# Altium schematic sheet npm1300_qeaa_config3.SchDoc
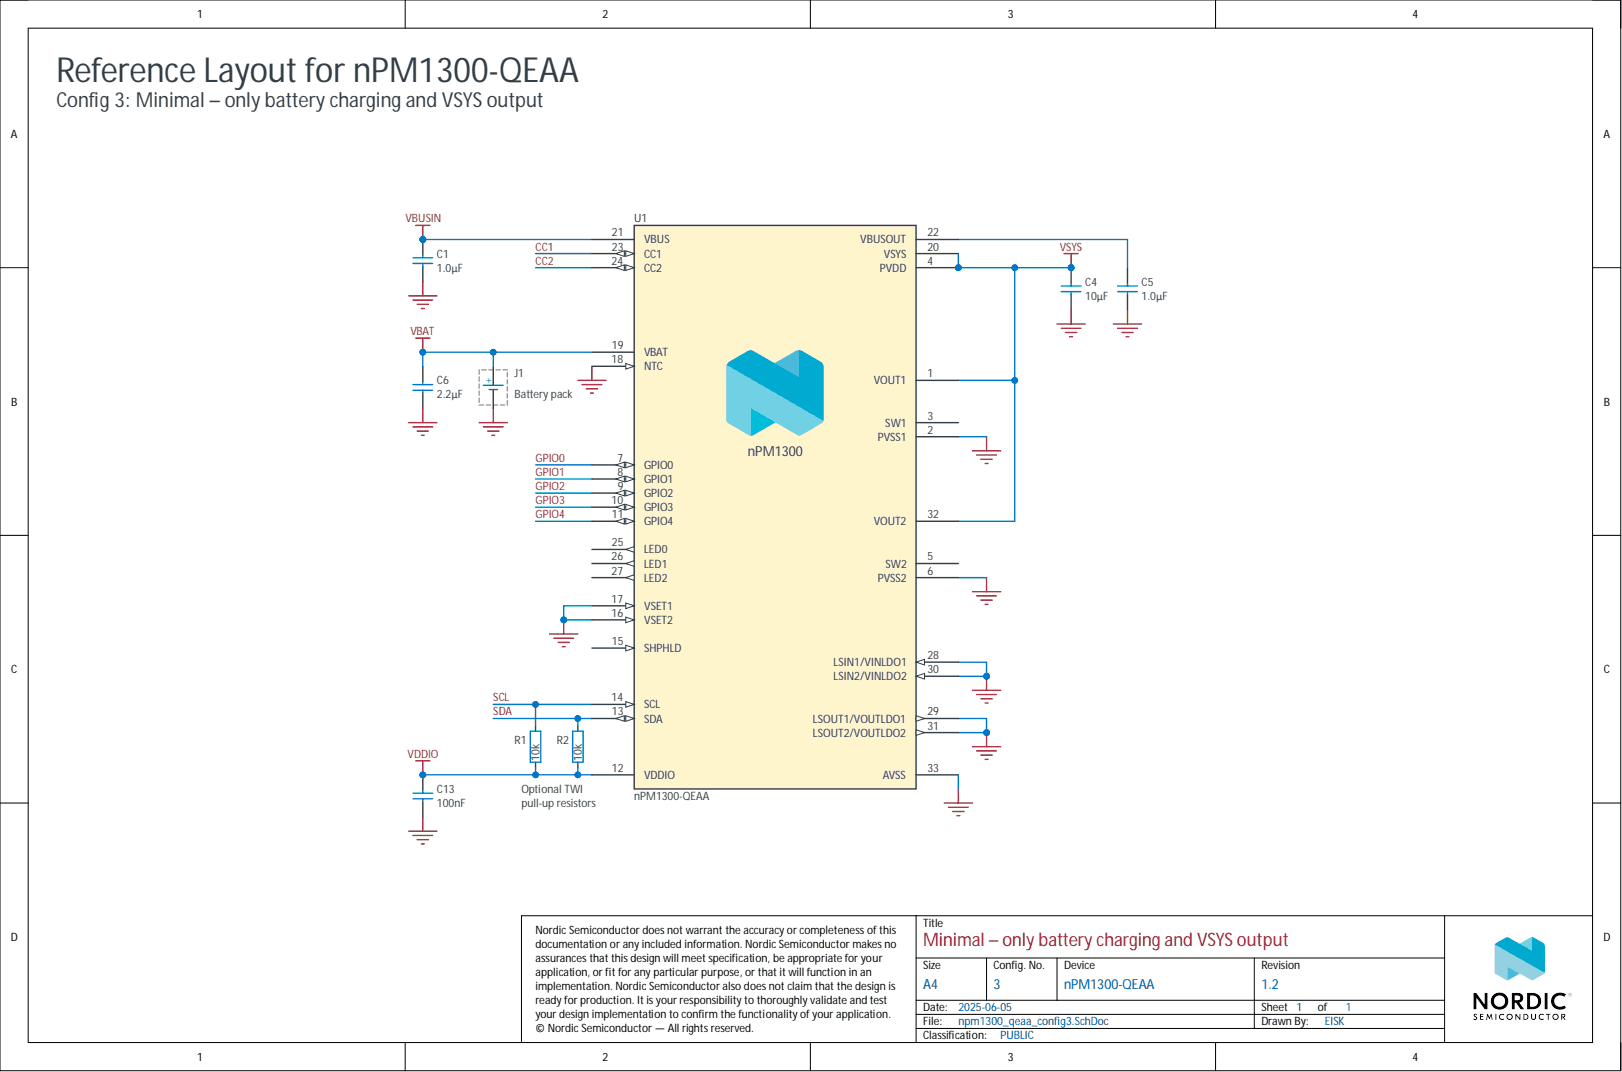
[diagram p0: npm1300_qeaa_config3.SchDoc]

## Parts
C1  1.0µF  [CAPC1608X06L]
C4  10µF  [CAPC1608X06L]
C5  1.0µF  [CAPC1608X06L]
C6  2.2µF  [CAPC1608X06L]
C13  100nF  [CAPC0603X03L_C]
R1  10k  [RESC0603X03L_C]
R2  10k  [RESC0603X03L_C]
U1  nPM1300-QEAA  [Nordic_QFN50P500X500X90-33N]

## Nets
GND: C1.1 C4.1 C5.1 C6.1 C13.2 U1.2(PVSS1) U1.6(PVSS2) U1.16(VSET2) U1.17(VSET1) U1.18(NTC) U1.28(LSIN1/VINLDO1) U1.29(LSOUT1/VOUTLDO1) U1.30(LSIN2/VINLDO2) U1.31(LSOUT2/VOUTLDO2) U1.33(AVSS)
VBAT: C6.2 U1.19(VBAT)
VBUSIN: C1.2 U1.21(VBUS)
VDDIO: C13.1 R1.2 R2.2 U1.12(VDDIO)
VSYS: C4.2 U1.1(VOUT1) U1.4(PVDD) U1.20(VSYS) U1.32(VOUT2)
CC1: U1.23(CC1)
CC2: U1.24(CC2)
GPIO0: U1.7(GPIO0)
GPIO1: U1.8(GPIO1)
GPIO2: U1.9(GPIO2)
GPIO3: U1.10(GPIO3)
GPIO4: U1.11(GPIO4)
SCL: R1.1 U1.14(SCL)
SDA: R2.1 U1.13(SDA)
NetC5_2: C5.2 U1.22(VBUSOUT)

Not connected: U1 3(SW1) 5(SW2) 15(SHPHLD) 25(LED0) 26(LED1) 27(LED2)

## Library data of the parts
C1 C5 = C0603-1µ0-10V-X5R: Capacitor, Ceramic, 1.0µF 10V X5R 0603,±10%; Part number NEMS: 100200528; Artikkelnr Noca: N.A.; Component Status: Active; Database Table Name: Parts$; Pad Count: 2; Component Class: Capacitors; Component Kind: Standard; ComponentLink1Description: Datasheet; ComponentLink1URL: N.A.; ComponentLink2Description: Supplier link; ComponentLink2URL: N.A.; Footprint Doc: 0603; HelpURL: N.A.; LatestRevisionDate: 2022-10-06; Library Name: AltiumLib.DbLib; Manufacturer: N.A.; Manufacturer Part Number: N.A.; Note: 10V; Part Number: C0603-1µ0-10V-X5R; Part number IPS: N.A.; Part number Norautron: N.A.; PartId: 100200528; Published: 2022-10-06; Supplier 1: N.A.; Supplier 2: N.A.; Supplier Part Number 1: N.A.; Supplier Part Number 2: N.A.; Value: 1.0µF; Author: getr
C4 = C0603-10µ: Capacitor, X5R, ±20%; Manufacturer: N.A.; Part number IPS: 00000007785; Part number NEMS: 100200275; Artikkelnr Noca: 20039234; Component Status: Active; Database Table Name: Parts$; Note: 6.3V; Pad Count: 2; Component Class: Capacitors; Component Kind: Standard; ComponentLink1Description: Datasheet; ComponentLink1URL: N.A.; ComponentLink2Description: Supplier link; ComponentLink2URL: N.A.; Footprint Doc: 0603; HelpURL: N.A.; LatestRevisionDate: 2011-01-17; Library Name: AltiumLib.DbLib; Manufacturer Part Number: N.A.; Part Number: C0603-10µ; Part number Norautron: N.A.; PartId: 100200275; Published: 2011-01-17; Supplier 1: N.A.; Supplier 2: N.A.; Supplier Part Number 1: N.A.; Supplier Part Number 2: N.A.; Value: 10µF; Author: rsk
C6 = C0603-2.2µ-16V-X7R: Capacitor, Ceramic, X7R, ±10%, 16V; Manufacturer: Taiyo Yuden; Manufacturer Part Number: EMK107BB7225KA-T; Part number NEMS: 100200439; Artikkelnr Noca: N.A.; Component Status: Active; Database Table Name: Parts$; Pad Count: 2; Component Class: Capacitors; Component Kind: Standard; ComponentLink1Description: Datasheet; ComponentLink1URL: https://ds.yuden.co.jp/TYCOMPAS/ut/specSheet?pn=EMK107BB7225KA-T; ComponentLink2Description: Supplier link; ComponentLink2URL: https://ds.yuden.co.jp/TYCOMPAS/ut/detail?pn=EMK107BB7225KA-T&u=M; Footprint Doc: 0603; HelpURL: https://www.digikey.no/product-detail/en/taiyo-yuden/EMK107BB7225KA-T/587-5835-2-ND/4970646; LatestRevisionDate: 2020-10-13; Library Name: AltiumLib.DbLib; Note: 16V; Part Number: C0603-2.2µ-16V-X7R; Part number IPS: N.A.; Part number Norautron: N.A.; PartId: 100200439; Published: 2020-02-03; Supplier 1: Digi-Key; Supplier 2: N.A.; Supplier Part Number 1: 587-5835-2-ND; Supplier Part Number 2: N.A.; Value: 2.2µF; Author: rsk
C13 = C0201-100n: Capacitor, X5R, ±10%, 100nF, 16V; Part number IPS: 00000001248, 00000002505; Part number NEMS: 100200078; Part number Norautron: 262071862; Artikkelnr Noca: N.A.; Component Status: Active; Database Table Name: Parts$; Pad Count: 2; Component Class: Capacitors; Component Kind: Standard; ComponentLink1Description: Datasheet; ComponentLink1URL: N.A.; ComponentLink2Description: Supplier link; ComponentLink2URL: N.A.; Footprint Doc: 0201; HelpURL: N.A.; LatestRevisionDate: 2024-06-27; Library Name: AltiumLib.DbLib; Manufacturer: N.A.; Manufacturer Part Number: N.A.; Note: 16V; Part Number: C0201-100n; PartId: 100200078; Published: 2014-11-13; Supplier 1: N.A.; Supplier 2: N.A.; Supplier Part Number 1: N.A.; Supplier Part Number 2: N.A.; Value: 100nF; Author: rsk
R1 R2 = R0201-10k: Resistor, ±1%, 0.05W; Part number IPS: 00000001255; Part number NEMS: 100100065; Part number Norautron: 26107101; Artikkelnr Noca: N.A.; Component Status: Active; Database Table Name: Parts$; Note: Chip Res 1%; Pad Count: 2; Component Class: Resistors; Component Kind: Standard; ComponentLink1Description: Datasheet; ComponentLink1URL: N.A.; ComponentLink2Description: Supplier link; ComponentLink2URL: N.A.; Footprint Doc: 0201; HelpURL: N.A.; LatestRevisionDate: 2014-11-13; Library Name: AltiumLib.DbLib; Manufacturer: N.A.; Manufacturer Part Number: N.A.; Part Number: R0201-10k; PartId: 100100065; Published: 2014-11-13; Supplier 1: N.A.; Supplier 2: N.A.; Supplier Part Number 1: N.A.; Supplier Part Number 2: N.A.; Value: 10k; Author: rsk
U1 = nPM1300-QEAA: Li-Ion/Li-Polymer USB-C battery charger with high efficiency buck regulators, LDOs or load switches, LED drivers, TWI and VBUSOUT; Manufacturer: Nordic Semiconductor; Part number NEMS: 102000252; Artikkelnr Noca: N.A.; Component Status: Active; Database Table Name: Parts$; Pad Count: 33; Component Class: nRF_Devices; Component Kind: Standard; ComponentLink1Description: Datasheet; ComponentLink1URL: N.A.; ComponentLink2Description: Supplier link; ComponentLink2URL: N.A.; Footprint Doc: QFN-32; HelpURL: N.A.; LatestRevisionDate: 2022-11-14; Library Name: AltiumLib.DbLib; Manufacturer Part Number: nPM1300-QEAA; Note: N.A.; Part Number: nPM1300-QEAA; Part number IPS: N.A.; Part number Norautron: N.A.; PartId: 102000252; Published: 2022-02-17; Supplier 1: Nordic Semiconductor; Supplier 2: N.A.; Supplier Part Number 1: nPM1300-QEAA; Supplier Part Number 2: N.A.; Value: nPM1300-QEAA; Author: eisk
